# Altium schematic sheet DDR3 Power.SchDoc
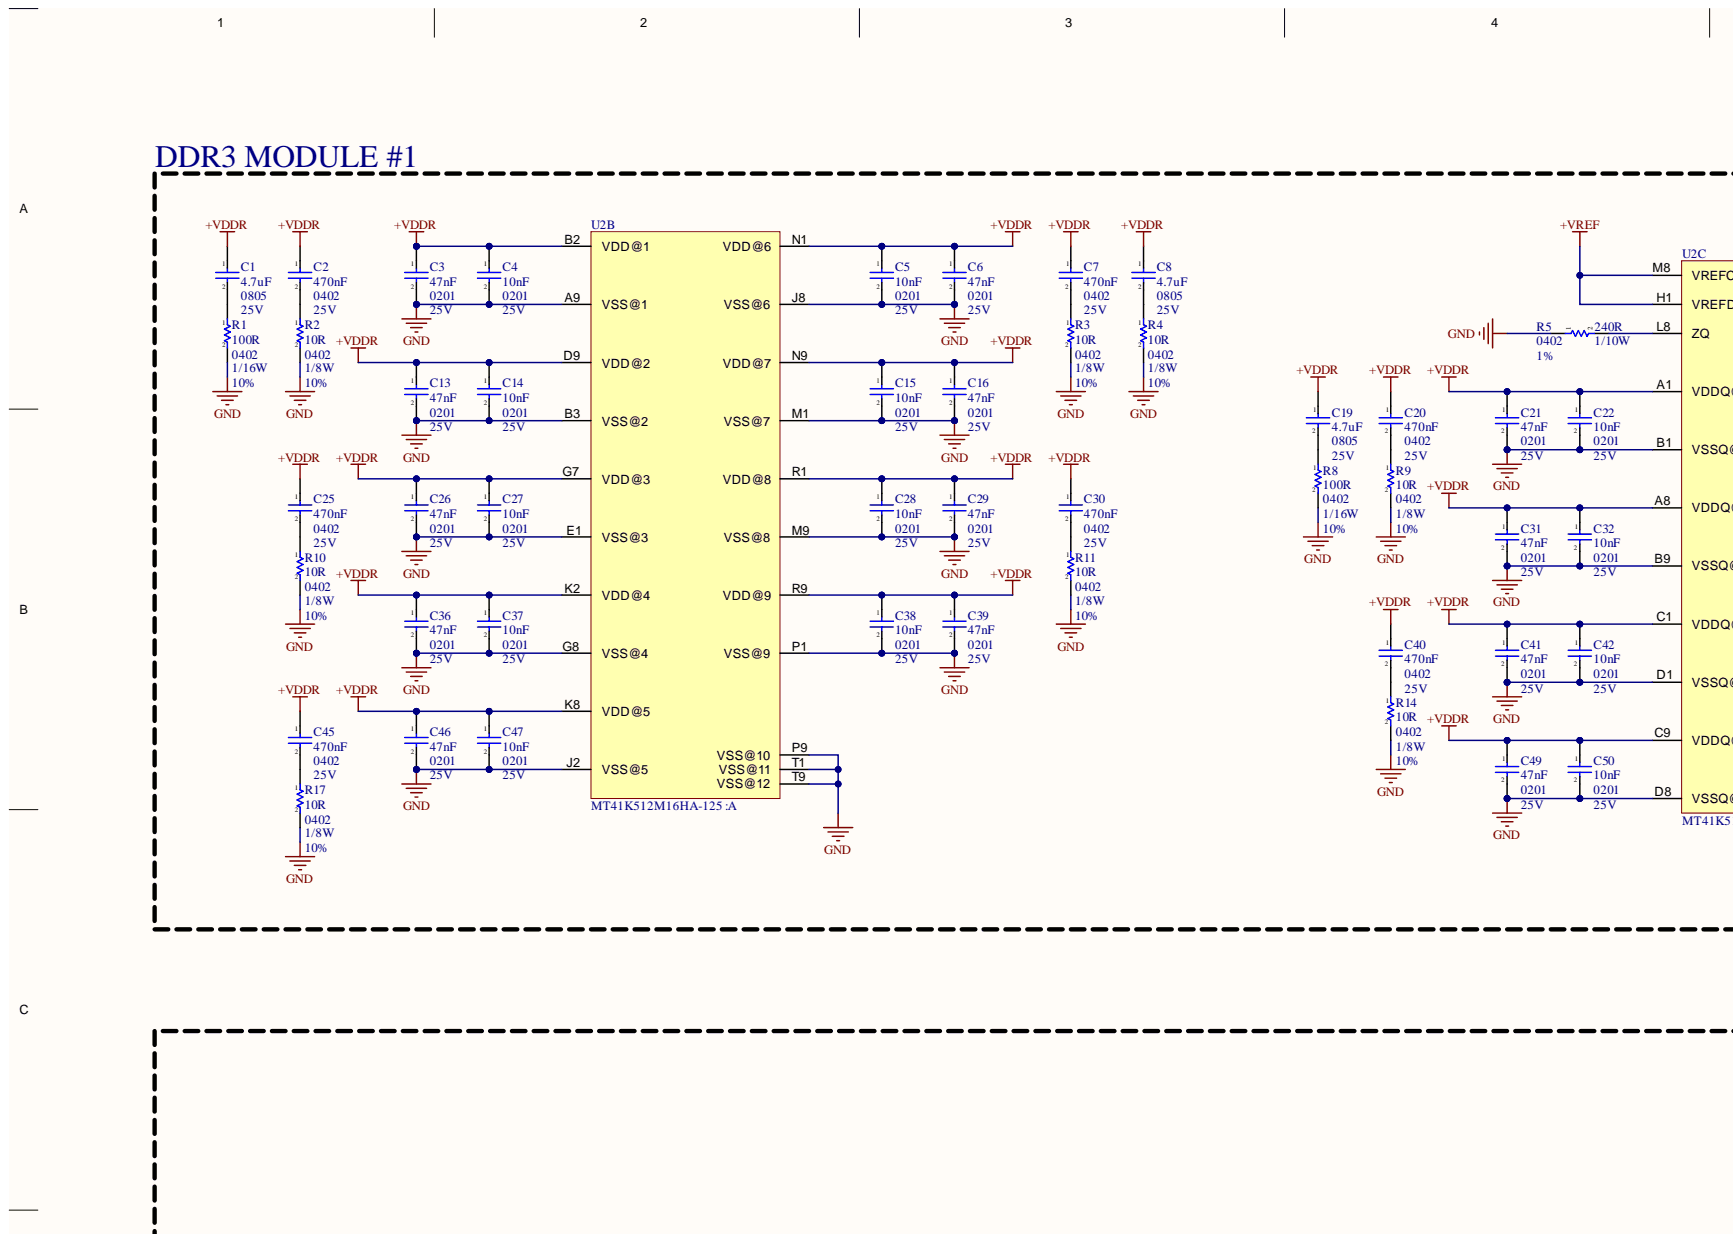
[diagram p0: DDR3 Power.SchDoc, top left]
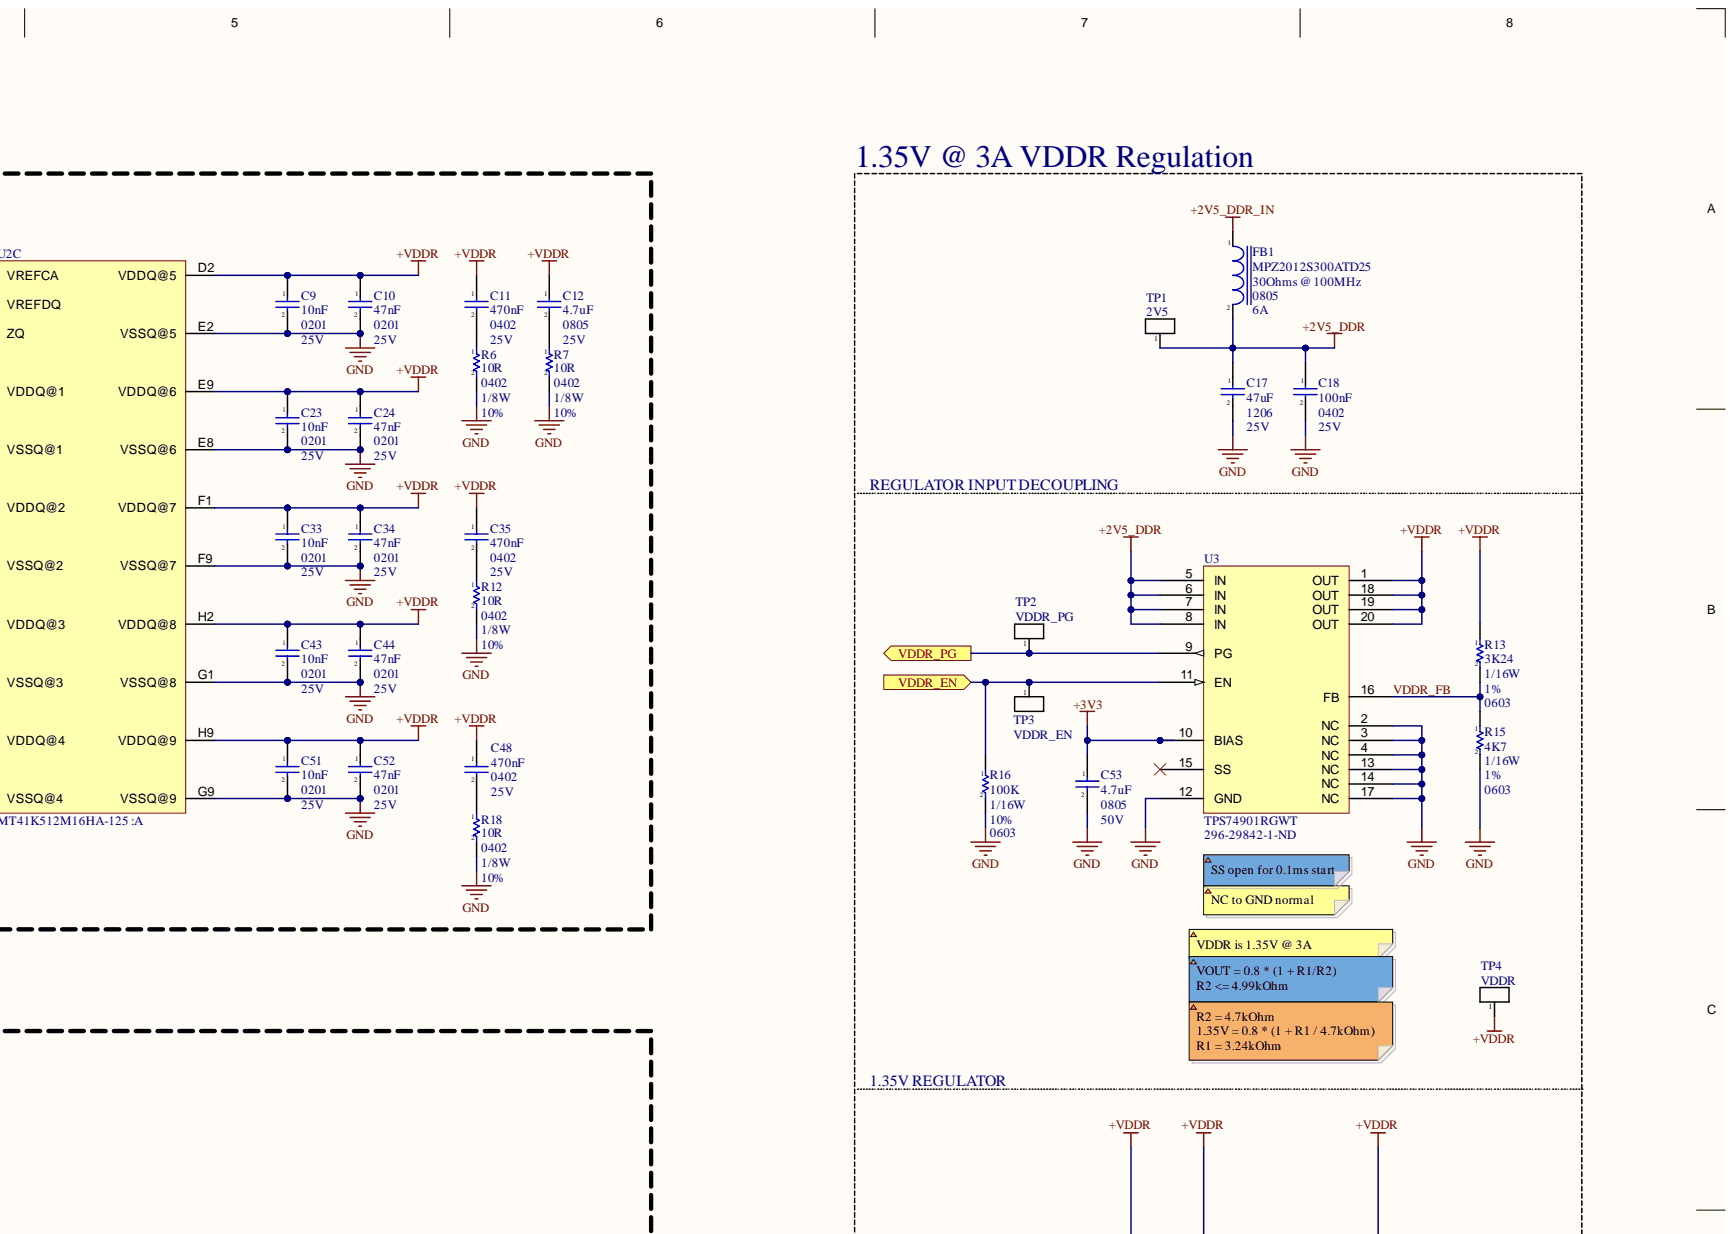
[diagram p1: DDR3 Power.SchDoc, top right]
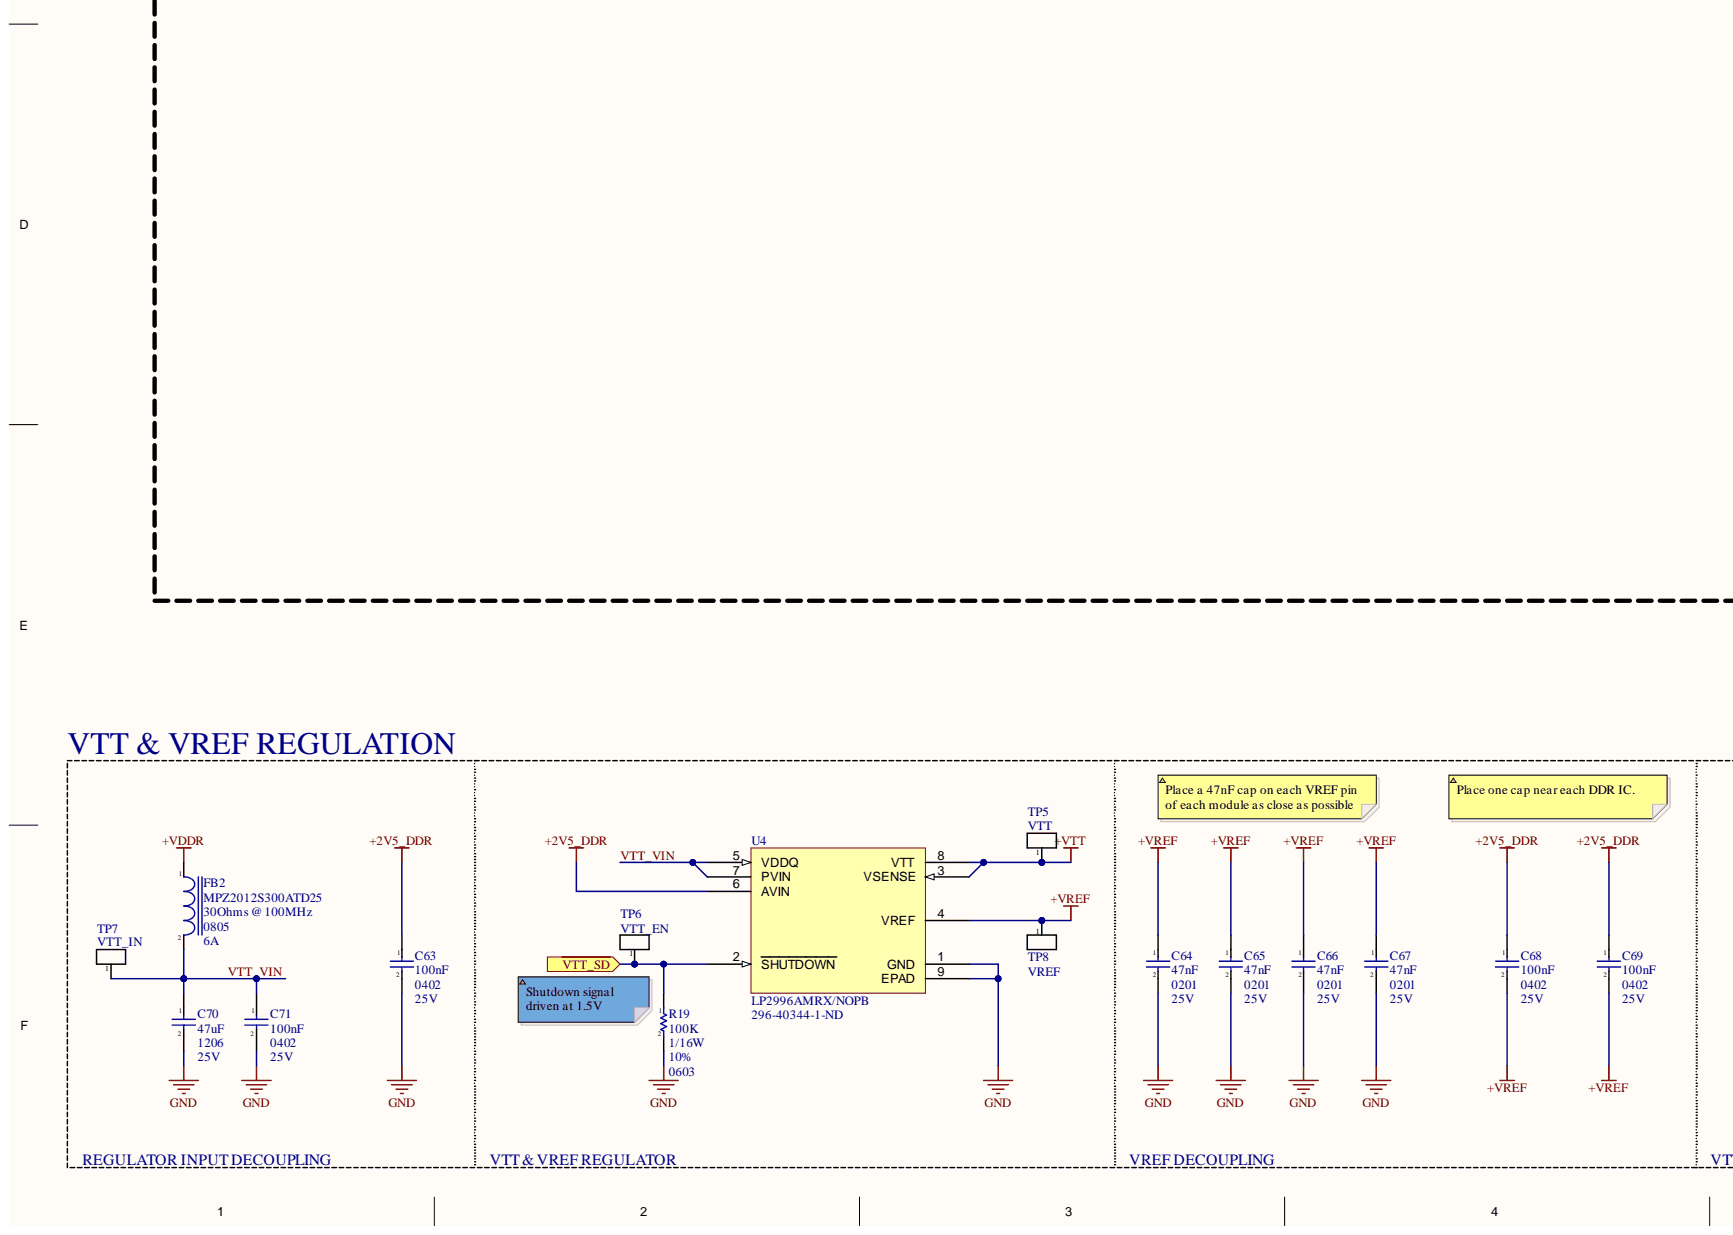
[diagram p2: DDR3 Power.SchDoc, bottom left]
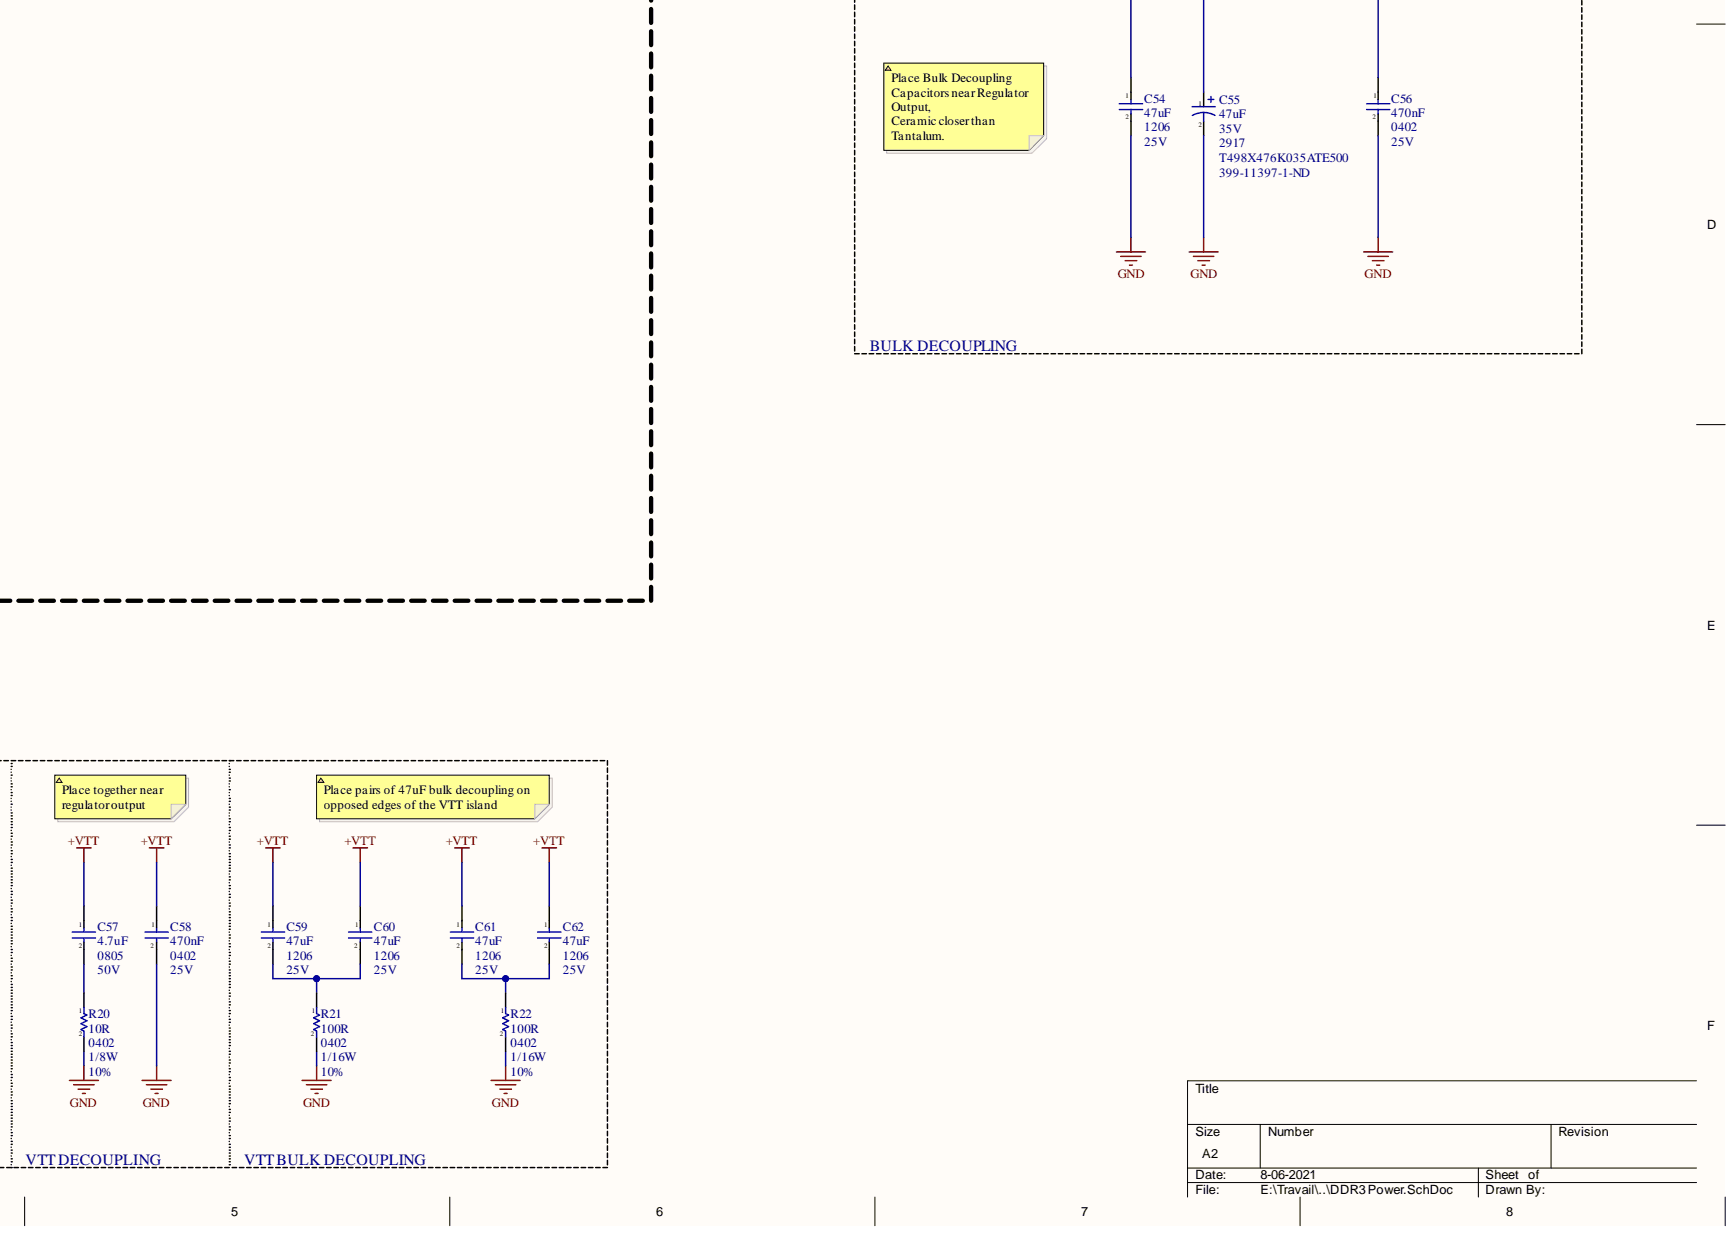
[diagram p3: DDR3 Power.SchDoc, bottom right]

## Parts
C1  4.7uF  Voltage - Rated: 25V  [0805]
C2  470nF  Voltage - Rated: 25V  [0402]
C3  47nF  Voltage - Rated: 25V  [0201]
C4  10nF  Voltage - Rated: 25V  [0201]
C5  10nF  Voltage - Rated: 25V  [0201]
C6  47nF  Voltage - Rated: 25V  [0201]
C7  470nF  Voltage - Rated: 25V  [0402]
C8  4.7uF  Voltage - Rated: 25V  [0805]
C9  10nF  Voltage - Rated: 25V  [0201]
C10  47nF  Voltage - Rated: 25V  [0201]
C11  470nF  Voltage - Rated: 25V  [0402]
C12  4.7uF  Voltage - Rated: 25V  [0805]
C13  47nF  Voltage - Rated: 25V  [0201]
C14  10nF  Voltage - Rated: 25V  [0201]
C15  10nF  Voltage - Rated: 25V  [0201]
C16  47nF  Voltage - Rated: 25V  [0201]
C17  47uF  Voltage - Rated: 25V  [1206]
C18  100nF  Voltage - Rated: 25V  [0402]
C19  4.7uF  Voltage - Rated: 25V  [0805]
C20  470nF  Voltage - Rated: 25V  [0402]
C21  47nF  Voltage - Rated: 25V  [0201]
C22  10nF  Voltage - Rated: 25V  [0201]
C23  10nF  Voltage - Rated: 25V  [0201]
C24  47nF  Voltage - Rated: 25V  [0201]
C25  470nF  Voltage - Rated: 25V  [0402]
C26  47nF  Voltage - Rated: 25V  [0201]
C27  10nF  Voltage - Rated: 25V  [0201]
C28  10nF  Voltage - Rated: 25V  [0201]
C29  47nF  Voltage - Rated: 25V  [0201]
C30  470nF  Voltage - Rated: 25V  [0402]
C31  47nF  Voltage - Rated: 25V  [0201]
C32  10nF  Voltage - Rated: 25V  [0201]
C33  10nF  Voltage - Rated: 25V  [0201]
C34  47nF  Voltage - Rated: 25V  [0201]
C35  470nF  Voltage - Rated: 25V  [0402]
C36  47nF  Voltage - Rated: 25V  [0201]
C37  10nF  Voltage - Rated: 25V  [0201]
C38  10nF  Voltage - Rated: 25V  [0201]
C39  47nF  Voltage - Rated: 25V  [0201]
C40  470nF  Voltage - Rated: 25V  [0402]
C41  47nF  Voltage - Rated: 25V  [0201]
C42  10nF  Voltage - Rated: 25V  [0201]
C43  10nF  Voltage - Rated: 25V  [0201]
C44  47nF  Voltage - Rated: 25V  [0201]
C45  470nF  Voltage - Rated: 25V  [0402]
C46  47nF  Voltage - Rated: 25V  [0201]
C47  10nF  Voltage - Rated: 25V  [0201]
C48  470nF  Voltage - Rated: 25V  [0402]
C49  47nF  Voltage - Rated: 25V  [0201]
C50  10nF  Voltage - Rated: 25V  [0201]
C51  10nF  Voltage - Rated: 25V  [0201]
C52  47nF  Voltage - Rated: 25V  [0201]
C53  4.7uF  Voltage - Rated: 50V  [0805]
C54  47uF  Voltage - Rated: 25V  [1206]
C55  47uF  Package: 2917  Part #: T498X476K035ATE500  Rated Voltage: 35V  Supplier Part Number 1: 399-11397-1-ND  [CAP_2917_P]
C56  470nF  Voltage - Rated: 25V  [0402]
C57  4.7uF  Voltage - Rated: 50V  [0805]
C58  470nF  Voltage - Rated: 25V  [0402]
C59  47uF  Voltage - Rated: 25V  [1206]
C60  47uF  Voltage - Rated: 25V  [1206]
C61  47uF  Voltage - Rated: 25V  [1206]
C62  47uF  Voltage - Rated: 25V  [1206]
C63  100nF  Voltage - Rated: 25V  [0402]
C64  47nF  Voltage - Rated: 25V  [0201]
C65  47nF  Voltage - Rated: 25V  [0201]
C66  47nF  Voltage - Rated: 25V  [0201]
C67  47nF  Voltage - Rated: 25V  [0201]
C68  100nF  Voltage - Rated: 25V  [0402]
C69  100nF  Voltage - Rated: 25V  [0402]
C70  47uF  Voltage - Rated: 25V  [1206]
C71  100nF  Voltage - Rated: 25V  [0402]
FB1  MPZ2012S300ATD25  Current Rating: 6A  Package / Case: 0805  [IND_0805]
FB2  MPZ2012S300ATD25  Current Rating: 6A  Package / Case: 0805  [IND_0805]
R1  100R  Package / Case: 0402  Power: 1/16W  Tolerance: 10%  [RES_0402]
R2  10R  Package / Case: 0402  Power: 1/8W  Tolerance: 10%  [RES_0402]
R3  10R  Package / Case: 0402  Power: 1/8W  Tolerance: 10%  [RES_0402]
R4  10R  Package / Case: 0402  Power: 1/8W  Tolerance: 10%  [RES_0402]
R5  240R  Package / Case: 0402  Power: 1/10W  Tolerance: 1%  [RES_0402]
R6  10R  Package / Case: 0402  Power: 1/8W  Tolerance: 10%  [RES_0402]
R7  10R  Package / Case: 0402  Power: 1/8W  Tolerance: 10%  [RES_0402]
R8  100R  Package / Case: 0402  Power: 1/16W  Tolerance: 10%  [RES_0402]
R9  10R  Package / Case: 0402  Power: 1/8W  Tolerance: 10%  [RES_0402]
R10  10R  Package / Case: 0402  Power: 1/8W  Tolerance: 10%  [RES_0402]
R11  10R  Package / Case: 0402  Power: 1/8W  Tolerance: 10%  [RES_0402]
R12  10R  Package / Case: 0402  Power: 1/8W  Tolerance: 10%  [RES_0402]
R13  3K24  Power: 1/16W  Tolerance: 1%  [RES_0603]
R14  10R  Package / Case: 0402  Power: 1/8W  Tolerance: 10%  [RES_0402]
R15  4K7  Package / Case: 0603  Power: 1/16W  Tolerance: 1%  [RES_0603]
R16  100K  Package / Case: 0603  Power: 1/16W  Tolerance: 10%  [RES_0603]
R17  10R  Package / Case: 0402  Power: 1/8W  Tolerance: 10%  [RES_0402]
R18  10R  Package / Case: 0402  Power: 1/8W  Tolerance: 10%  [RES_0402]
R19  100K  Package / Case: 0603  Power: 1/16W  Tolerance: 10%  [RES_0603]
R20  10R  Package / Case: 0402  Power: 1/8W  Tolerance: 10%  [RES_0402]
R21  100R  Package / Case: 0402  Power: 1/16W  Tolerance: 10%  [RES_0402]
R22  100R  Package / Case: 0402  Power: 1/16W  Tolerance: 10%  [RES_0402]
TP1  2V5  [TP_HOLE]
TP2  VDDR_PG  [TP_HOLE]
TP3  VDDR_EN  [TP_HOLE]
TP4  VDDR  [TP_HOLE]
TP5  VTT  [TP_HOLE]
TP6  VTT_EN  [TP_PAD]
TP7  VTT_IN  [TP_HOLE]
TP8  VREF  [TP_HOLE]
U2  MT41K512M16HA-125 :A  [FBGA-96]  (parts B,C)
U3  TPS74901RGWT  Supplier Part Number 1: 296-29842-1-ND  [TPS74901RGWT]
U4  LP2996AMRX/NOPB  Supplier Part Number 1: 296-40344-1-ND  [LP2996AMRX]

## Ports
VDDR_EN, VDDR_PG, ~{VTT_SD}

## Nets
+2V5_DDR: C17.1 C18.1 C63.1 C68.1 C69.1 FB1.2 TP1.1 U3.5(IN) U3.6(IN) U3.7(IN) U3.8(IN) U4.6(AVIN)
+2V5_DDR_IN: FB1.1
+3V3: C53.1 U3.10(BIAS)
+VDDR: C1.1 C2.1 C3.1 C4.1 C5.1 C6.1 C7.1 C8.1 C9.1 C10.1 C11.1 C12.1 C13.1 C14.1 C15.1 C16.1 C19.1 C20.1 C21.1 C22.1 C23.1 C24.1 C25.1 C26.1 C27.1 C28.1 C29.1 C30.1 C31.1 C32.1 C33.1 C34.1 C35.1 C36.1 C37.1 C38.1 C39.1 C40.1 C41.1 C42.1 C43.1 C44.1 C45.1 C46.1 C47.1 C48.1 C49.1 C50.1 C51.1 C52.1 C54.1 C55.1 C56.1 FB2.1 R13.1 TP4.1 U2.A1(VDDQ@1) U2.A8(VDDQ@2) U2.B2(VDD@1) U2.C1(VDDQ@3) U2.C9(VDDQ@4) U2.D2(VDDQ@5) U2.D9(VDD@2) U2.E9(VDDQ@6) U2.F1(VDDQ@7) U2.G7(VDD@3) U2.H2(VDDQ@8) U2.H9(VDDQ@9) U2.K2(VDD@4) U2.K8(VDD@5) U2.N1(VDD@6) U2.N9(VDD@7) U2.R1(VDD@8) U2.R9(VDD@9) U3.1(OUT) U3.18(OUT) U3.19(OUT) U3.20(OUT)
+VREF: C64.1 C65.1 C66.1 C67.1 C68.2 C69.2 TP8.1 U2.H1(VREFDQ) U2.M8(VREFCA) U4.4(VREF)
+VTT: C57.1 C58.1 C59.1 C60.1 C61.1 C62.1 TP5.1 U4.3(VSENSE) U4.8(VTT)
GND: C3.2 C4.2 C5.2 C6.2 C9.2 C10.2 C13.2 C14.2 C15.2 C16.2 C17.2 C18.2 C21.2 C22.2 C23.2 C24.2 C26.2 C27.2 C28.2 C29.2 C31.2 C32.2 C33.2 C34.2 C36.2 C37.2 C38.2 C39.2 C41.2 C42.2 C43.2 C44.2 C46.2 C47.2 C49.2 C50.2 C51.2 C52.2 C53.2 C54.2 C55.2 C56.2 C58.2 C63.2 C64.2 C65.2 C66.2 C67.2 C70.2 C71.2 R1.2 R2.2 R3.2 R4.2 R5.1 R6.2 R7.2 R8.2 R9.2 R10.2 R11.2 R12.2 R14.2 R15.2 R16.2 R17.2 R18.2 R19.2 R20.2 R21.2 R22.2 U2.A9(VSS@1) U2.B1(VSSQ@1) U2.B3(VSS@2) U2.B9(VSSQ@2) U2.D1(VSSQ@3) U2.D8(VSSQ@4) U2.E1(VSS@3) U2.E2(VSSQ@5) U2.E8(VSSQ@6) U2.F9(VSSQ@7) U2.G1(VSSQ@8) U2.G8(VSS@4) U2.G9(VSSQ@9) U2.J2(VSS@5) U2.J8(VSS@6) U2.M1(VSS@7) U2.M9(VSS@8) U2.P1(VSS@9) U2.P9(VSS@10) U2.T1(VSS@11) U2.T9(VSS@12) U3.2(NC) U3.3(NC) U3.4(NC) U3.12(GND) U3.13(NC) U3.14(NC) U3.17(NC) U4.1(GND) U4.9(EPAD)
~{VTT_SD}: R19.1 TP6.1 U4.2(SHUTDOWN) ; port ~{VTT_SD}
VDDR_EN: R16.1 TP3.1 U3.11(EN) ; port VDDR_EN
VDDR_FB: R13.2 R15.1 U3.16(FB)
VDDR_PG: TP2.1 U3.9(PG) ; port VDDR_PG
VTT_VIN: C70.1 C71.1 FB2.2 TP7.1 U4.5(VDDQ) U4.7(PVIN)
NetC1_2: C1.2 R1.1
NetC2_2: C2.2 R2.1
NetC7_2: C7.2 R3.1
NetC8_2: C8.2 R4.1
NetC11_2: C11.2 R6.1
NetC12_2: C12.2 R7.1
NetC19_2: C19.2 R8.1
NetC20_2: C20.2 R9.1
NetC25_2: C25.2 R10.1
NetC30_2: C30.2 R11.1
NetC35_2: C35.2 R12.1
NetC40_2: C40.2 R14.1
NetC45_2: C45.2 R17.1
NetC48_2: C48.2 R18.1
NetC57_2: C57.2 R20.1
NetC59_2: C59.2 C60.2 R21.1
NetC61_2: C61.2 C62.2 R22.1
NetR5_2: R5.2 U2.L8(ZQ)

Not connected: U3 15(SS)

## Library data of the parts
C1-C54 C56-C71 = CAP_CER: Generic SMT Ceramic Capacitor; Component Kind: Standard; ComponentLink1Description: Digikey; ComponentLink1URL: [Digikey Link]; ComponentLink2Description: Datasheet; ComponentLink2URL: [Datasheet Link]
  C1 C8 C12 C19 C53 C57: Capacitance: 4.7uF
  C1-C16 C18-C53 C56-C58 C63-C69 C71: Library Name: RaesLib.IntLib
  C17 C54 C59-C62 C70: Library Name: CryptLib.IntLib
C55 = CAP_T498X476K035ATE500: 47 µF Molded Tantalum Capacitors 35 V 2917 (7343 Metric) 500mOhm; Mfr: KEMET; Tolerance: 10%; Component Kind: Standard; Operating Temperature: -55C - 150C; Supplier 1: Digi-Key; Library Name: CryptLib.IntLib
FB1 FB2 = IND_MPZ2012S300ATD25: 30 Ohms @ 100 MHz 1 Power Line Ferrite Bead 0805 (2012 Metric) 6A 10mOhm; Resistance: 10mOhms; Component Kind: Standard; Impedance @ Freq: 30Ohms @ 100MHz; Operating Temperature: -55C - 125C; Rating: AEC-Q200; Library Name: CryptLib.IntLib
R1-R22 = RESISTOR: Component Kind: Standard; ComponentLink1Description: Digikey; Description: Resistor; Library Name: Symbols.SchLib
  R13: Package / Case: 0603
TP1-TP8 = TESTPOINT: Test Point; Component Kind: Standard; Library Name: Symbols.SchLib
U2 = IC_MT41K512M16HA-125: DRAM 8G 1.35V 512Mx16 800 MHz DDR3 0C-90C; Mfr: Alliance Memory; Data Bus Width: 16 bits; Maximum Clock Frequency: 800MHz; Memory Size: 8Gb; Supply Current (Max): 88mA; Supply Voltage (Max): 1.45V; Supply Voltage (Min): 1.283V; Component Kind: Standard; Memory Organization: 512M x 16; Operating Temperature: 0C - 95C; Package / Case: FBGA-96; Type: SDRAM - DDR3L; Library Name: CryptLib.SchLib
U3 = IC_TPS74901RGWT: IC REG LINEAR POS ADJ 3A 20VQFN; Mfr: Texas Instruments; Max Input Voltage: 5.5V; Max Output Voltage: 3.6V; Min Output Voltage: 0.8V; Component Kind: Standard; Max Current: 3A; Operating Temperature: -40C - 125C; Supplier 1: Digi-Key; Library Name: CryptLib.SchLib
U4 = IC_LP2996AMRX: IC REGULATOR DDR TERM 8SOPWRPAD; Mfr: Texas Instruments; Continuous Current: 1.5A; Supply Voltage: 2.2V - 5.5V; Applications: DDR3 Terminator; Component Kind: Standard; Operating Temperature: 0C - 125C; Supplier 1: Digi-Key; Library Name: CryptLib.SchLib
